# Altium schematic sheet High Voltage.SchDoc("High Voltage")
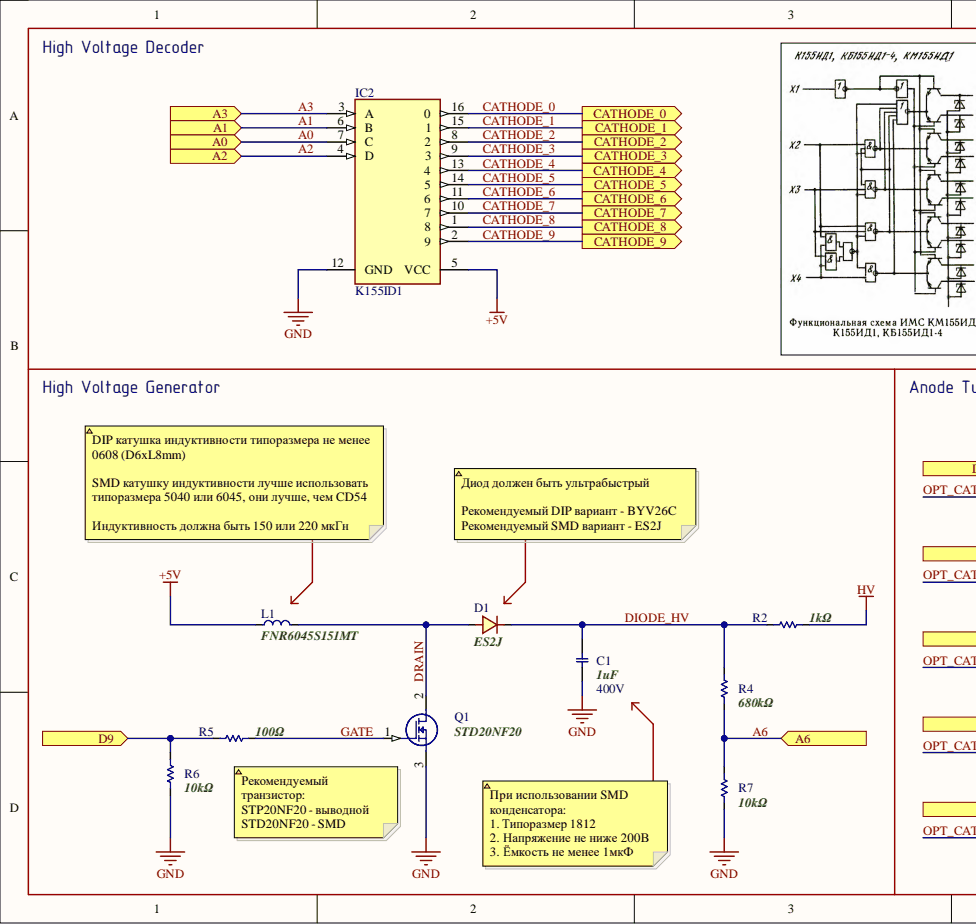
[diagram p0: High Voltage.SchDoc, left]
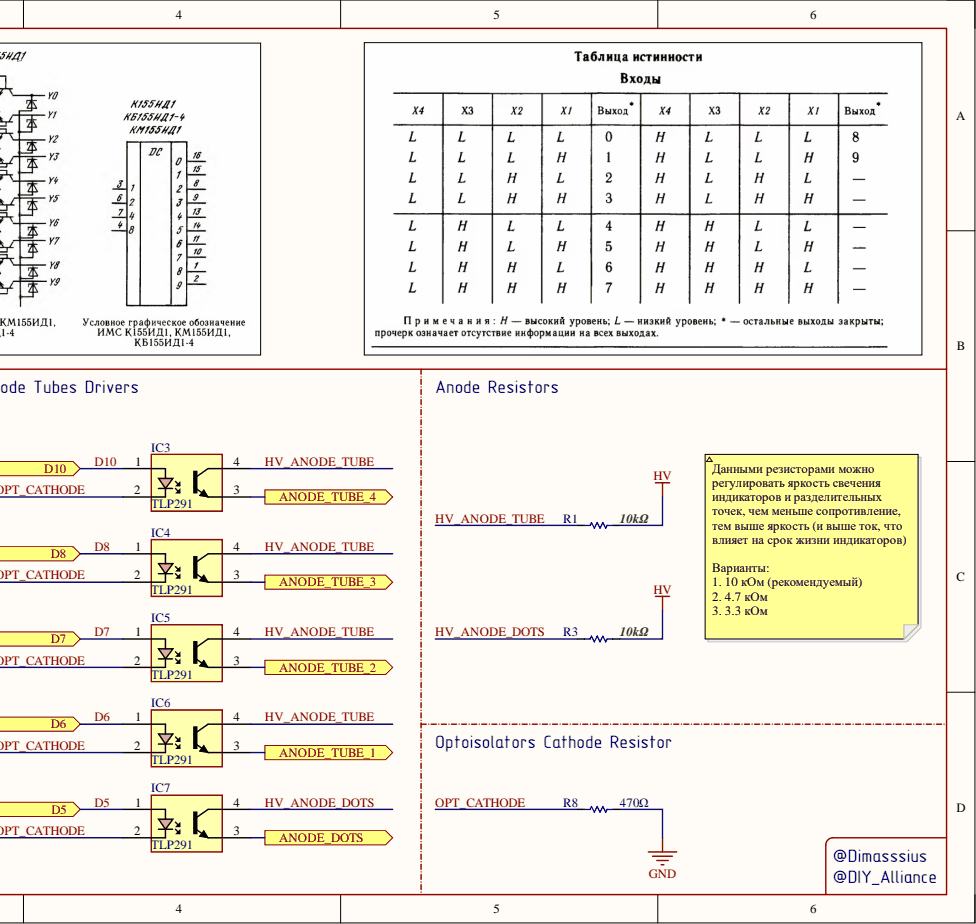
[diagram p1: High Voltage.SchDoc, right]

## Parts
C1  1uF  [CAP_THD_ELEC_ALUM_D8.0MM_H12.5MM_SHORT _SMD_C1828_#3_SMD]
C1
C1
D1  ES2J  [DO-41/DO-214AC_VERTICAL_SMD/THD_SMD]
D1
D1
IC2  K155ID1  [DIP16]
IC3  TLP291  [SOIC127P700X230-4N]
IC4  TLP291  [SOIC127P700X230-4N]
IC5  TLP291  [SOIC127P700X230-4N]
IC6  TLP291  [SOIC127P700X230-4N]
IC7  TLP291  [SOIC127P700X230-4N]
L1  FNR6045S151MT  [XR6*8-151K-L_FNR6045S151MT_SMD]
L1
L1
Q1  STD20NF20  [TO-220_THD/SMD_SMD]
Q1
Q1
R1  10kΩ  [RES_THD/SMD_VERTICAL_R0603_R0402_10K_SMD]
R1
R1
R2  1kΩ  [RES_THD/SMD_VERTICAL_R0603_R0402_1K_SMD]
R2
R2
R3  10kΩ  [RES_THD/SMD_VERTICAL_R0603_R0402_10K_SMD]
R3
R3
R4  680kΩ  [RES_THD/SMD_VERTICAL_R0603_R0402_680K_SMD]
R4
R4
R5  100Ω  [RES_THD/SMD_VERTICAL_R0603_R0402_100OHM_SMD]
R5
R5
R6  10kΩ  [RES_THD/SMD_VERTICAL_R0603_R0402_10K_SMD]
R6
R6
R7  10kΩ  [RES_THD/SMD_VERTICAL_R0603_R0402_10K_SMD]
R7
R7
R8  470Ω  [RES_THD/SMD_VERTICAL_R0603_R0402_470OHM_SMD]
R8
R8

## Ports
A0, A1, A2, A3, A6, ANODE_DOTS, ANODE_TUBE_1, ANODE_TUBE_2, ANODE_TUBE_3, ANODE_TUBE_4, CATHODE_0, CATHODE_1, CATHODE_2, CATHODE_3, CATHODE_4, CATHODE_5, CATHODE_6, CATHODE_7, CATHODE_8, CATHODE_9, D5, D6, D7, D8, D9, D10

## Nets
+5V: IC2.5(VCC) L1.1
GND: C1.1 C1.2 IC2.12(GND) Q1.3 R6.2 R7.2 R8.1
HV: R1.1 R2.1 R3.2
A0: IC2.7(C) ; port A0
A1: IC2.6(B) ; port A1
A2: IC2.4(D) ; port A2
A3: IC2.3(A) ; port A3
A6: R4.2 R7.1 ; port A6
ANODE_DOTS: IC7.3 ; port ANODE_DOTS
ANODE_TUBE_1: IC6.3 ; port ANODE_TUBE_1
ANODE_TUBE_2: IC5.3 ; port ANODE_TUBE_2
ANODE_TUBE_3: IC4.3 ; port ANODE_TUBE_3
ANODE_TUBE_4: IC3.3 ; port ANODE_TUBE_4
CATHODE_0: IC2.16 ; port CATHODE_0
CATHODE_1: IC2.15 ; port CATHODE_1
CATHODE_2: IC2.8 ; port CATHODE_2
CATHODE_3: IC2.9 ; port CATHODE_3
CATHODE_4: IC2.13 ; port CATHODE_4
CATHODE_5: IC2.14 ; port CATHODE_5
CATHODE_6: IC2.11 ; port CATHODE_6
CATHODE_7: IC2.10 ; port CATHODE_7
CATHODE_8: IC2.1 ; port CATHODE_8
CATHODE_9: IC2.2 ; port CATHODE_9
D5: IC7.1 ; port D5
D6: IC6.1 ; port D6
D7: IC5.1 ; port D7
D8: IC4.1 ; port D8
D9: R5.2 R6.1 ; port D9
D10: IC3.1 ; port D10
DIODE_HV: C1.1 C1.2 D1.1 R2.2 R4.1
DRAIN: D1.2 L1.2 Q1.2
GATE: Q1.1 R5.1
HV_ANODE_DOTS: IC7.4 R3.1
HV_ANODE_TUBE: IC3.4 IC4.4 IC5.4 IC6.4 R1.2
OPT_CATHODE: IC3.2 IC4.2 IC5.2 IC6.2 IC7.2 R8.2

## Library data of the parts
C1 = CAP_SMD_MLCC_1UF_250V: Capacitor, Ceramic (MLCC), SMD, 1uF, 250V, ±10%, X7R, Multilayer Ceramic Capacitors MLCC - SMD/SMT, ROHS; Manufacturer: Murata Electronics North America; Part Number: GRM319R61A105KA01D; @ qty: 0; Minimum Quantity: 1; Temperature Coefficient: X5R; Unit Price (USD): 0.28; Voltage: 10V; Component Kind: Standard; Library Name: Capacitors.SchLib; Value: 1uF; Applications: General Purpose; Datasheets: http://www.murata.com/~/media/webrenewal/support/library/catalog/products/capacitor/mlcc/c02e.pdf; Digi-Key Part Number: 490-11607-1-ND; Factory Stock: 0; Features: -; Height - Seated (Max): -; Image: //media.digikey.com/Renders/Murata%20Renders/GR331BD72E473KW01L.jpg; Lead Spacing: -; Lead Style: -; Mounting Type: Surface Mount, MLCC; Operating Temperature: -55°C ~ 85°C; Package / Case: -; Packaging: Cut Tape (CT); Part Status: Active; Quantity Available: 3593; Ratings: -; Series: GRM; Size / Dimension: -; Thickness (Max): -; Tolerance: ±10%
D1 = ES2J: Diode, SMD, SMA(DO-214AC), 600V, 2A, 35ns, 1.7V@2A, Fast Recovery / High Efficiency Diodes, ROHS; Component Kind: Standard; Datasheet Link: https://datasheet.datasheetarchive.com/originals/distributors/Datasheets_SAMA/69e8bf8de77318eea2cba0fbdd4d29c0.pdf; Library Name: SamacSys.SchLib; Value: ES2J; Height: 2.44mm
IC2 = K155ID1: Decoder, DIP-16, 5V±5%, 25mA, 150ns, High Voltage Output, BCD to Decimal Decoders, RoHS; Component Kind: Standard; Datasheet Link: http://pdf1.alldatasheet.com/datasheet-pdf/view/115763/TI/SN74141.html; Height: 5.08mm; Library Name: SamacSys.SchLib; Value: K155ID1
IC3-IC7 = TLP291: Photovoltaic Output Optoisolator, SOP-4-1.27mm, 80V, 3.75kV, 50mA, 300mV@2.4mA, 8mA, 1, 5V, 1.25V, DC  Transistor, Photovoltaic Output Optoisolators, ROHS; Component Kind: Standard; Datasheet Link: https://datasheet.datasheetarchive.com/originals/distributors/Datasheets-TOS/DSATOSJO10001087.pdf; Height: 2.3mm; Library Name: SamacSys.SchLib; Value: TLP291
L1 = FNR6045S151MT: Fixed Inductor, SMD, 6x6mm, 770mA, 150uH, Magnetic Shielded Inductor, ±20%, 880mA, 754mΩ, Fixed Inductors ROHS; Component Kind: Standard; Library Name: SamacSys.SchLib; Value: FNR6045S151MT
Q1 = STD20NF20: MOSFET (N-Channel), DPAK, 200V, 18A, 30W, 125mΩ@10V, 1 N-Channel, Single FETs, MOSFETs, RoHS; Component Kind: Standard; Datasheet Link: https://www.onsemi.com/pub/Collateral/FDD10N20LZ-D.pdf; Library Name: SamacSys.SchLib; Value: STD20NF20
R1 R3 R6 R7 = RES_THD/SMD_10KΩ_R0603_R0402_SMD: Resistor, Thick Film Resistor, SMD, 10kΩ, ±5%, Chip Resistor - Surface Mount, ROHS; Component Kind: Standard; Library Name: Resistors.SchLib; Value: 10kΩ
R2 = RES_THD/SMD_1KΩ_R0603_R0402_SMD: Resistor, Thick Film Resistor, SMD, 1kΩ, ±5%, Chip Resistor - Surface Mount, ROHS; Component Kind: Standard; Library Name: Resistors.SchLib; Value: 1kΩ
R4 = RES_THD/SMD_680kΩ_R0603_R0402_SMD: Resistor, Thick Film Resistor, SMD, 680kΩ, ±5%, Chip Resistor - Surface Mount, ROHS; Component Kind: Standard; Library Name: Resistors.SchLib; Value: 680kΩ
R5 = RES_THD/SMD_100Ω_R0603_R0402_SMD: Resistor, Thick Film Resistor, SMD, 100Ω, ±5%, Chip Resistor - Surface Mount, ROHS; Component Kind: Standard; Library Name: Resistors.SchLib; Value: 100Ω
R8 = RES_THD/SMD_470Ω_R0603_R0402_SMD: Resistor, Thick Film Resistor, SMD, 470Ω, ±5%, Chip Resistor - Surface Mount, ROHS; Component Kind: Standard; Library Name: Resistors.SchLib; Value: 470Ω
